# Altium schematic sheet Flyback.SchDoc (continued)
## Library data of the parts (continued)
R11 = R_Sense: TR / Current Sensors, 0805 0.25W, 5%  RL1220T-R020-J; Manufacturer: Susumu; Max Power Dissipation: 250mW; Resistance: 20mR; Temperature Coefficient: 350ppm/°C; Voltage Rating (DC): 100V; Case Code (Metric): 2012; Case Code (Imperial): 0805; Case/Package: 0805; Category: Resistors; Component Kind: Standard; ComponentLink1Description: https://datasheet.ciiva.com/2488/rl-data-sheet-2488002.pdf?src-supplier=Digi-Key; ComponentLink1URL: https://datasheet.ciiva.com/2488/rl-data-sheet-2488002.pdf?src-supplier=Digi-Key; ComponentLink2Description: https://datasheet.ciiva.com/2488/rl-data-sheet-2488003.pdf?src-supplier=Digi-Key; ComponentLink2URL: https://datasheet.ciiva.com/2488/rl-data-sheet-2488003.pdf?src-supplier=Digi-Key; ComponentLink3Description: https://datasheet.ciiva.com/2488/rl-data-sheet-2488004.pdf?src-supplier=Digi-Key; ComponentLink3URL: https://datasheet.ciiva.com/2488/rl-data-sheet-2488004.pdf?src-supplier=Digi-Key; ComponentLink4Description: https://datasheet.ciiva.com/12915/e-15-16-12915302.pdf?src-supplier=Mouser; ComponentLink4URL: https://datasheet.ciiva.com/12915/e-15-16-12915302.pdf?src-supplier=Mouser; Height: 0.028inch; Lead Free: Lead Free; Length: 0.079inch; Library Name: Flyback_Schlib.SchLib; Manufacturer Part Number: RL1220T-R020-J; Max Operating Temperature: 125°C; Min Operating Temperature: -55°C; Number of Terminations: 2; Package Quantity: 1; Packaging: Tape and Reel; Power Rating: 250mW; Pricing 1: 1=0,35000, 10=0,30000, 25=0,21840, 50=0,15840, 100=0,11740, 250=0,09008, 500=0,07098, 1000=0,04914 (USD); Resistor Type: Thin Film; RoHS: Yes; RoHS Compliant: Yes; Series: RL; Stock 1: 301682; Supplier 1: Digi-Key; Supplier Part Number 1: RL12T.020JCT-ND; Technology: THIN FILM; Termination: SMD/SMT; Tolerance: 5%; Weight: 0.000194oz; Width: 0.049inch
T1 = Transformer_CoilFormer: Epcos B66362W1014T001 ETD 34 Coil Former, 14 Pins  B66362W1014T001; Manufacturer: TDK EPCOS; Material: Polyterephthalate; Outside Diameter: 25.4mm; Inside Diameter: 20.9mm; Category: Coil Former; Component Kind: Standard; ComponentLink1Description: https://datasheet.ciiva.com/48906/70419804-48906870.pdf?src-supplier=Allied+Electronics; ComponentLink1URL: https://datasheet.ciiva.com/48906/70419804-48906870.pdf?src-supplier=Allied+Electronics; Library Name: Flyback_Schlib.SchLib; Manufacturer Part Number: B66362W1014T001; Max Operating Temperature: 180°C; Number of Pins: 14; Package Quantity: 100; Packaging: Bulk; Pricing 1: 1=1,99000, 10=1,71200, 25=1,30080, 50=1,23240, 100=1,09550, 250=1,02704, 500=0,95858, 1000=0,91065 (USD); RoHS: Yes; Supplier 1: Digi-Key; Supplier Part Number 1: 495-5465-ND; Termination: Pin; Weight: 1.410958oz
U1 = U_LT8316EFE#PBF: DC/DC Cntrlr Single-OUT 142kHz Automotive 16-Pin TSSOP EP Tube; Manufacturer: Analog Devices / Linear Technology; Component Kind: Standard; Library Name: Flyback_Schlib.SchLib; Manufacturer Part Number: LT8316EFE#PBF; Pricing 1: 1=5,81000, 10=5,22200, 25=4,93680, 100=4,27870, 250=4,05928, 500=3,64238, 1000=3,10500 (USD); RoHS: Yes; Supplier 1: Digi-Key; Supplier Part Number 1: LT8316EFE#PBF-ND
U2 = U_LT8309ES5#TRMPBF: IC REG FLYBACK ISO ADJ TSOT23-5; Manufacturer: Analog Devices / Linear Technology; Max Supply Voltage: 40V; Min Supply Voltage: 4.5V; Operating Supply Current: 300uA; Case/Package: SOT-23-5; Category: Voltage Regulators - Switching; Component Kind: Standard; ComponentLink1Description: https://datasheet.ciiva.com/29589/44473-29589472.pdf?src-supplier=Digi-Key; ComponentLink1URL: https://datasheet.ciiva.com/29589/44473-29589472.pdf?src-supplier=Digi-Key; ComponentLink2Description: https://datasheet.ciiva.com/29589/44473-29589470.pdf?src-supplier=Digi-Key; ComponentLink2URL: https://datasheet.ciiva.com/29589/44473-29589470.pdf?src-supplier=Digi-Key; ComponentLink3Description: https://datasheet.ciiva.com/29589/44473-29589471.pdf?src-supplier=Digi-Key; ComponentLink3URL: https://datasheet.ciiva.com/29589/44473-29589471.pdf?src-supplier=Digi-Key; Library Name: Flyback_Schlib.SchLib; Manufacturer Part Number: LT8309ES5#TRMPBF; Max Operating Temperature: 125°C; Min Operating Temperature: -40°C; Mount: Surface Mount; Number of Pins: 5; Packaging: Cut Tape; Pricing 1: 1=4,92000, 10=4,41500, 25=4,17360, 100=3,61720, 250=3,43176 (USD); REACH SVHC: No SVHC; RoHS: Yes; Supplier 1: Digi-Key; Supplier Part Number 1: LT8309ES5#TRMPBFCT-ND
